# Altium schematic sheet UI Board TOP.SchDoc
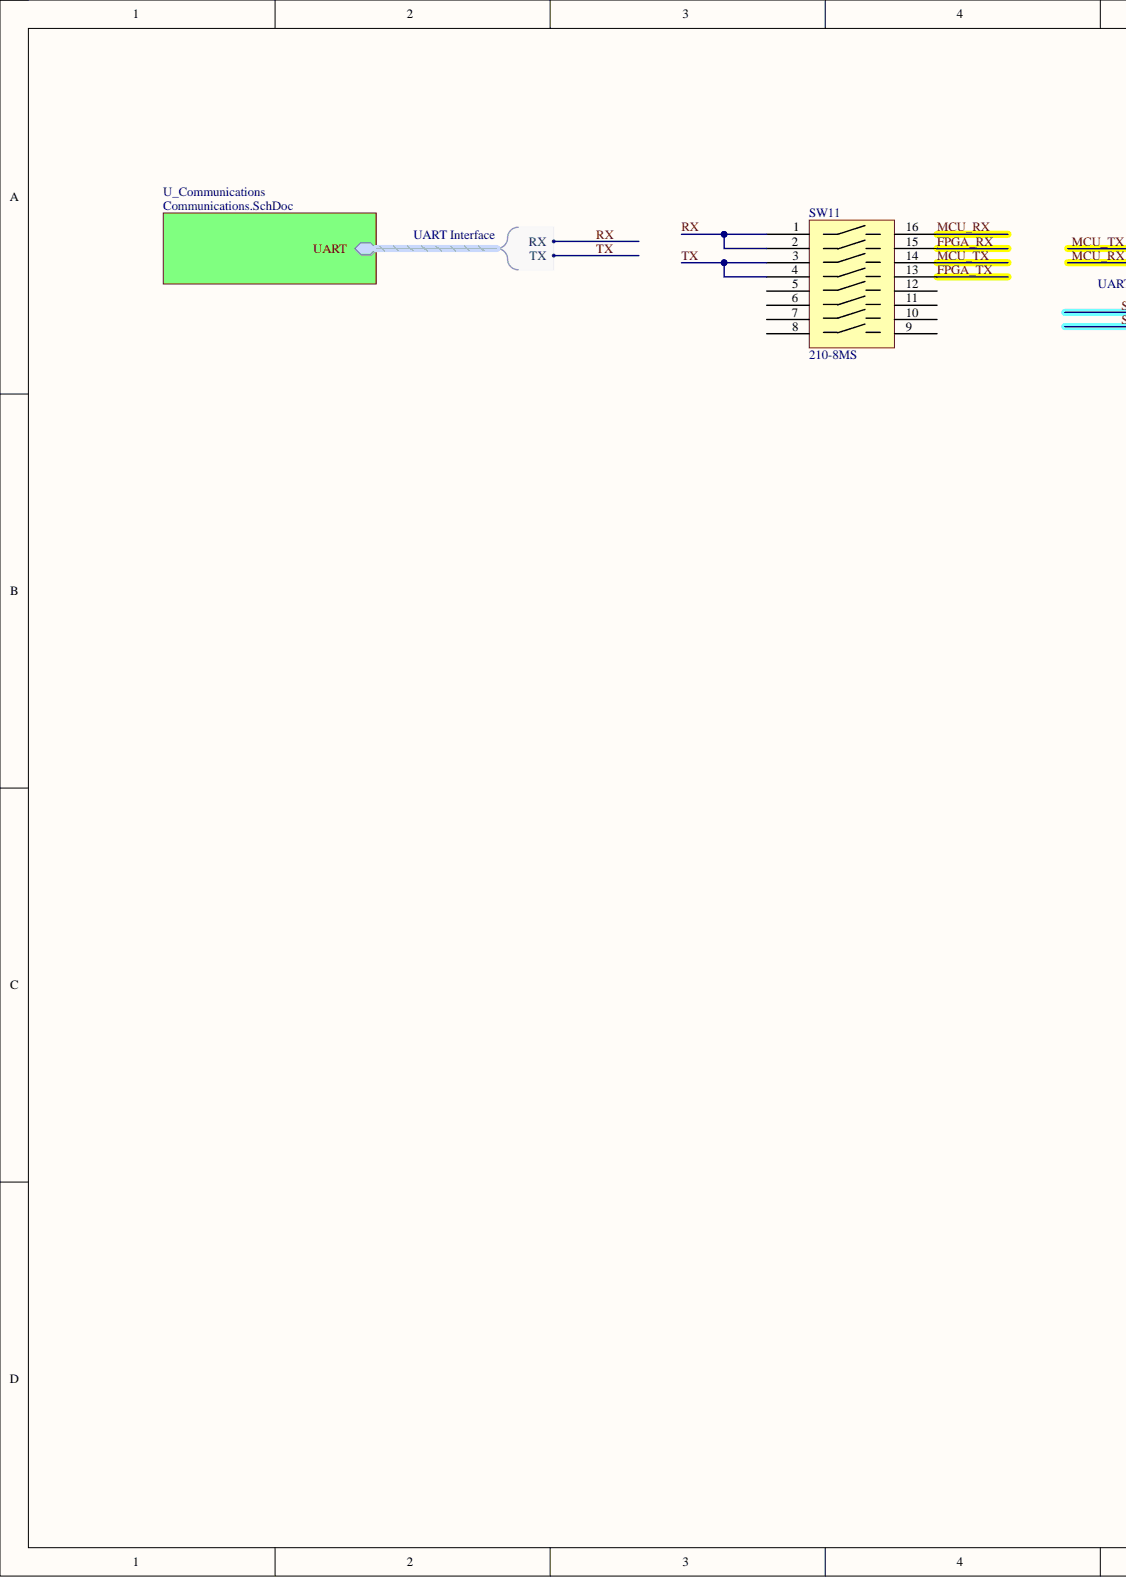
[diagram p0: UI Board TOP.SchDoc, left]
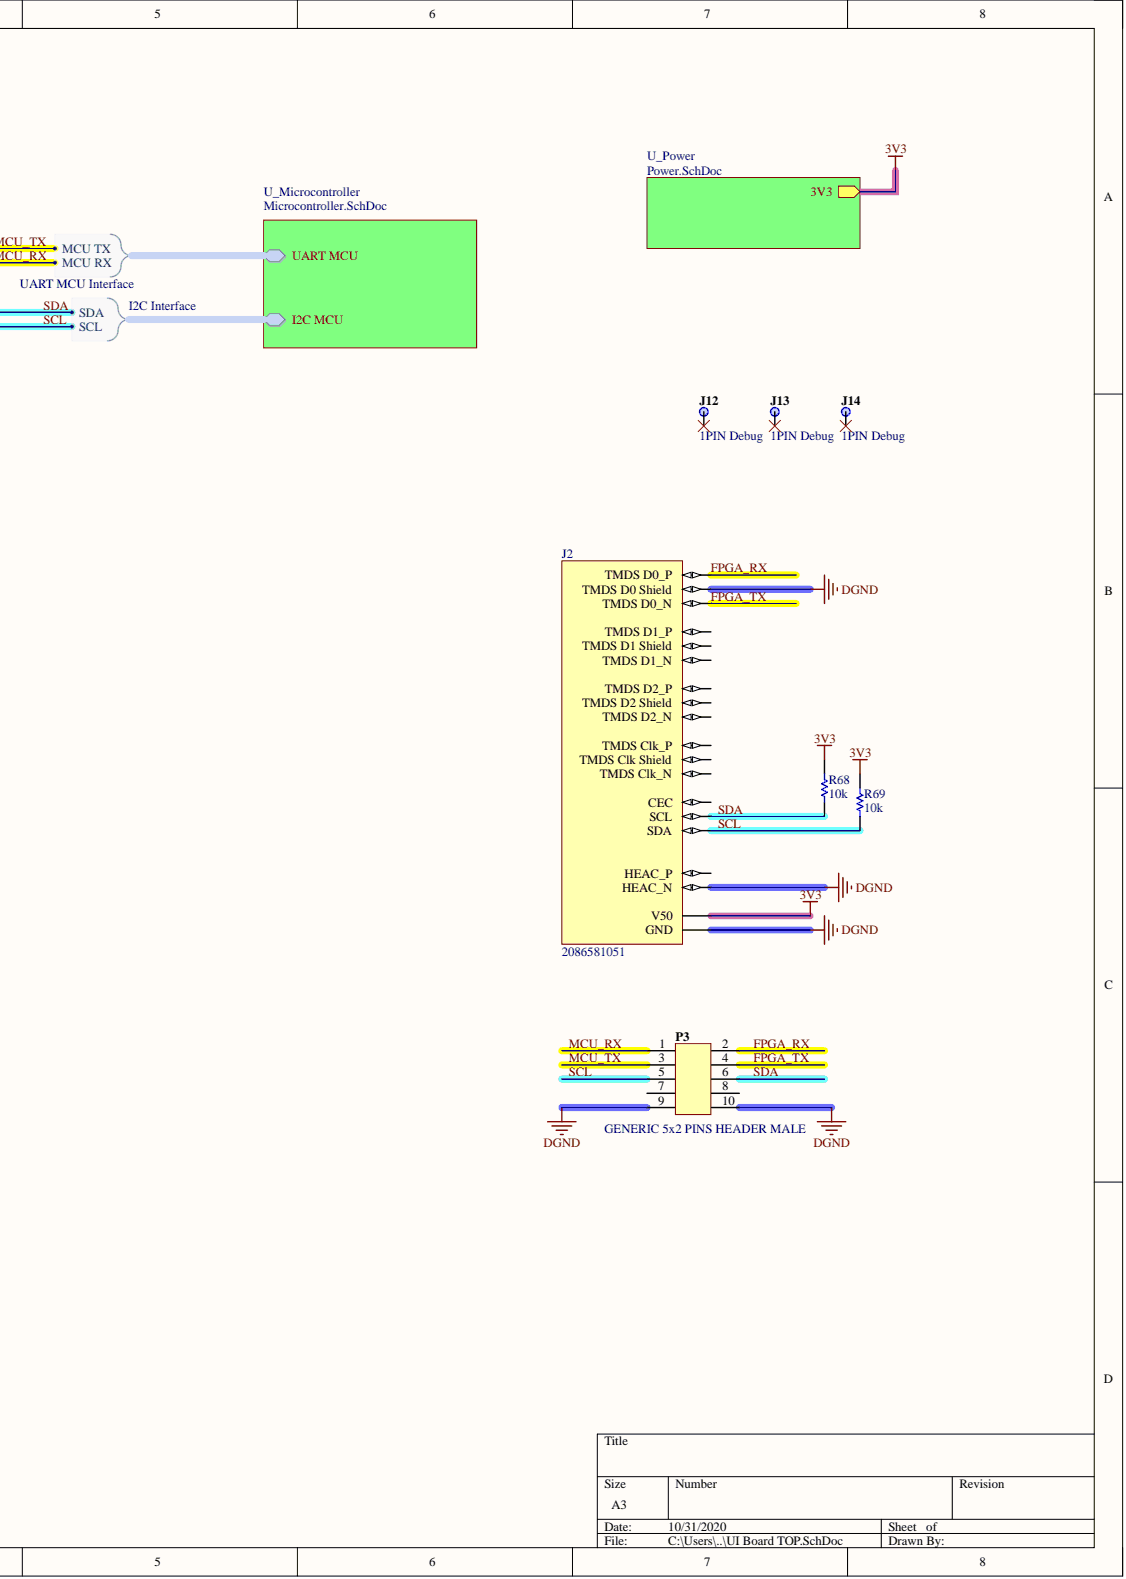
[diagram p1: UI Board TOP.SchDoc, right]

## Parts
J2  2086581051  [2086581051]
J12  1PIN Debug  [1 Pin Debug Port 0.9mm]
J13  1PIN Debug  [1 Pin Debug Port 0.9mm]
J14  1PIN Debug  [1 Pin Debug Port 0.9mm]
P3  GENERIC 5x2 PINS HEADER MALE  [GENERIC 5PIN 2ROW HEADER 0]
R68  value 10k  [0402-M]  (hidden comment: RES 10k 0402 63mW 5%)
R69  value 10k  [0402-M]  (hidden comment: RES 10k 0402 63mW 5%)
SW11  210-8MS  [210-8MS]

## Nets
3V3: J2.18(V50) R68.2 R69.2
DGND: J2.8(TMDS_D0_Shield) J2.17(GND) J2.19(HEAC_N) P3.9 P3.10
FPGA_RX: J2.7(TMDS_D0_P) P3.2 SW11.15
FPGA_TX: J2.9(TMDS_D0_N) P3.4 SW11.13
MCU_RX: P3.1 SW11.16
MCU_TX: P3.3 SW11.14
RX: SW11.1 SW11.2
SCL: J2.16(SDA) P3.5 R69.1
SDA: J2.15(SCL) P3.6 R68.1
TX: SW11.3 SW11.4
NetJ2_1: J2.1(TMDS_D2_P)
NetJ2_2: J2.2(TMDS_D2_Shield)
NetJ2_3: J2.3(TMDS_D2_N)
NetJ2_4: J2.4(TMDS_D1_P)
NetJ2_5: J2.5(TMDS_D1_Shield)
NetJ2_6: J2.6(TMDS_D1_N)
NetJ2_10: J2.10(TMDS_Clk_P)
NetJ2_11: J2.11(TMDS_Clk_Shield)
NetJ2_12: J2.12(TMDS_Clk_N)
NetJ2_13: J2.13(CEC)
NetJ2_14: J2.14(HEAC_P)
NetJ12_1: J12.1
NetJ13_1: J13.1
NetJ14_1: J14.1
NetP3_7: P3.7
NetP3_8: P3.8
NetSW11_5: SW11.5
NetSW11_6: SW11.6
NetSW11_7: SW11.7
NetSW11_8: SW11.8
NetSW11_9: SW11.9
NetSW11_10: SW11.10
NetSW11_11: SW11.11
NetSW11_12: SW11.12

## Library data of the parts
J2 = 2086581051-2: CONN FEM SMD 19 90Deg HDMI; Manufacturer: Molex; Case/Package: 4 PIN; Component Kind: Standard; Design Item ID: 2086581051; Source: Connector Library.SchLib; Status: Active; Supplier 1: Mouser; Supplier Part Number 1: Mouser; Type: HYBRID; Library Name: University of Malta - MEMENTO
J12-J14 = 1PIN Debug-2: CONN MAL TH 1 0Deg HEADER; Case/Package: 1 PIN; Component Kind: Standard; Design Item ID: 1PIN Debug; Source: Connector Library.SchLib; Status: NC; Type: N/A; Library Name: University of Malta - MEMENTO
P3 = GENERIC 5x2 PINS HEADER MALE-2: CONN MAL TH 5x2 0Deg HEADER; Bin: B3-J5; Case/Package: 2 PIN; Component Kind: Standard; Design Item ID: GENERIC 5x2 PINS HEADER MALE; Manufacturer: Generic; Source: Connector Library.SchLib; Status: Active; Type: TH; Library Name: University of Malta - MEMENTO
R68 R69 = RES 10k 0402 63mW 5%-1: RES-10k-0402-63mW-5%; Manufacturer: Generic; Bin: F1-R7-9; MAPPING: 1(2,1,11.5k); Case/Package: 0402; Component Kind: Standard; Design Item ID: RES 10k 0402 63mW 5%; Source: Resistor Library.SchLib; Status: Active; Type: SMD; Library Name: University of Malta - MEMENTO
SW11 = 210-8MS-2: SWITCH DIP SMD SPST 8 100mA 50V; Manufacturer: C&K Components; Case/Package: 16 PDIP; Component Kind: Standard; Design Item ID: 210-8MS; Source: Switches Library.SchLib; Status: Active; Supplier 1: Mouser; Supplier Part Number 1: 774-2108MS; Type: TH; Library Name: University of Malta - MEMENTO
